# Altium schematic sheet C3_AI_V2.SchDoc
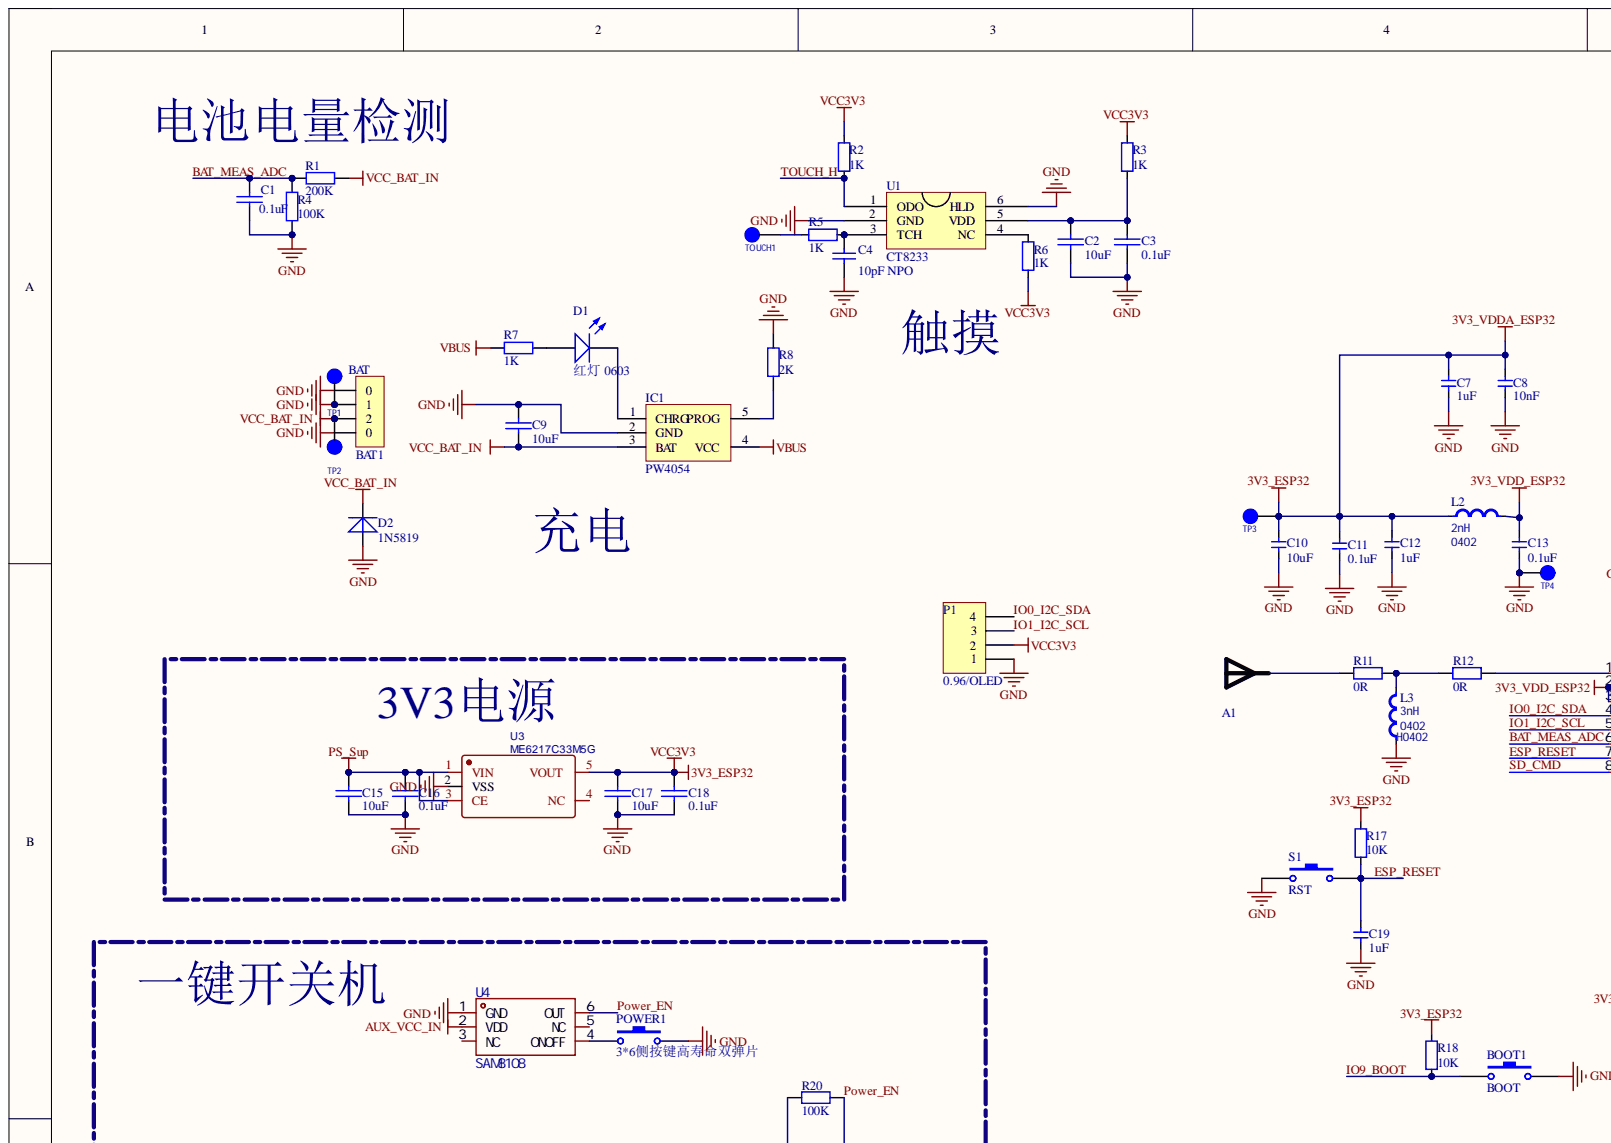
[diagram p0: C3_AI_V2.SchDoc, top left]
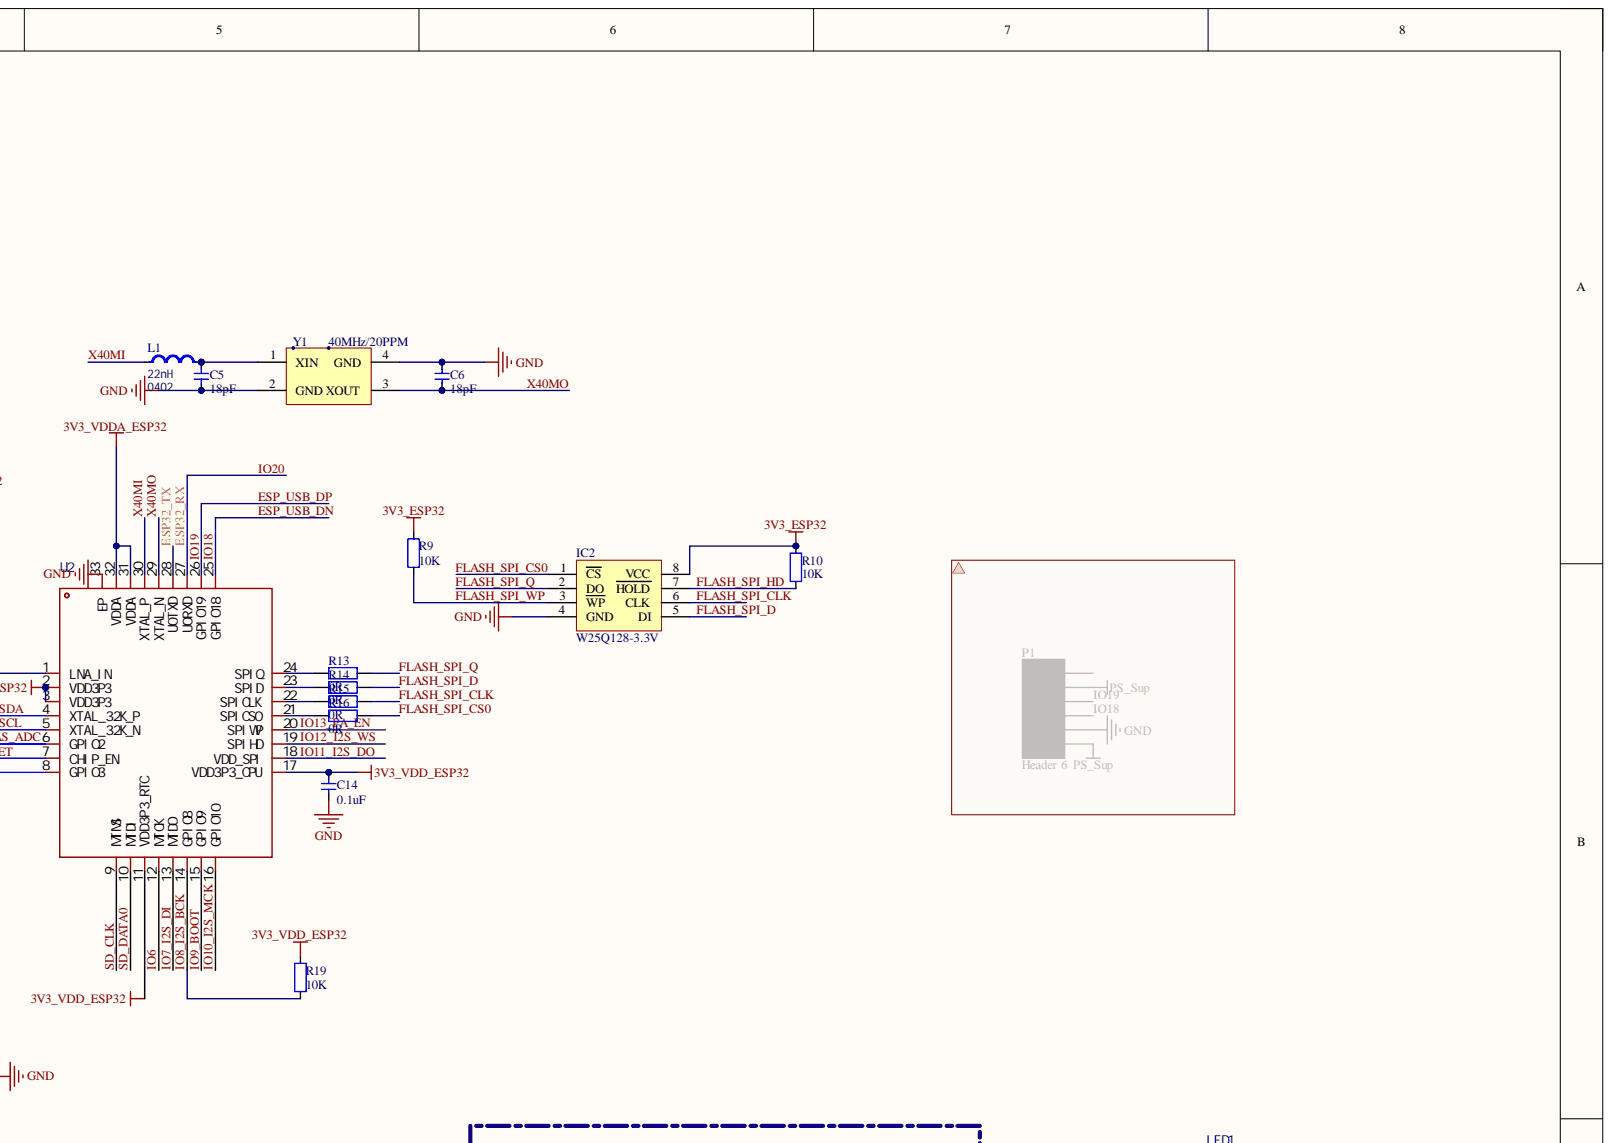
[diagram p1: C3_AI_V2.SchDoc, top right]
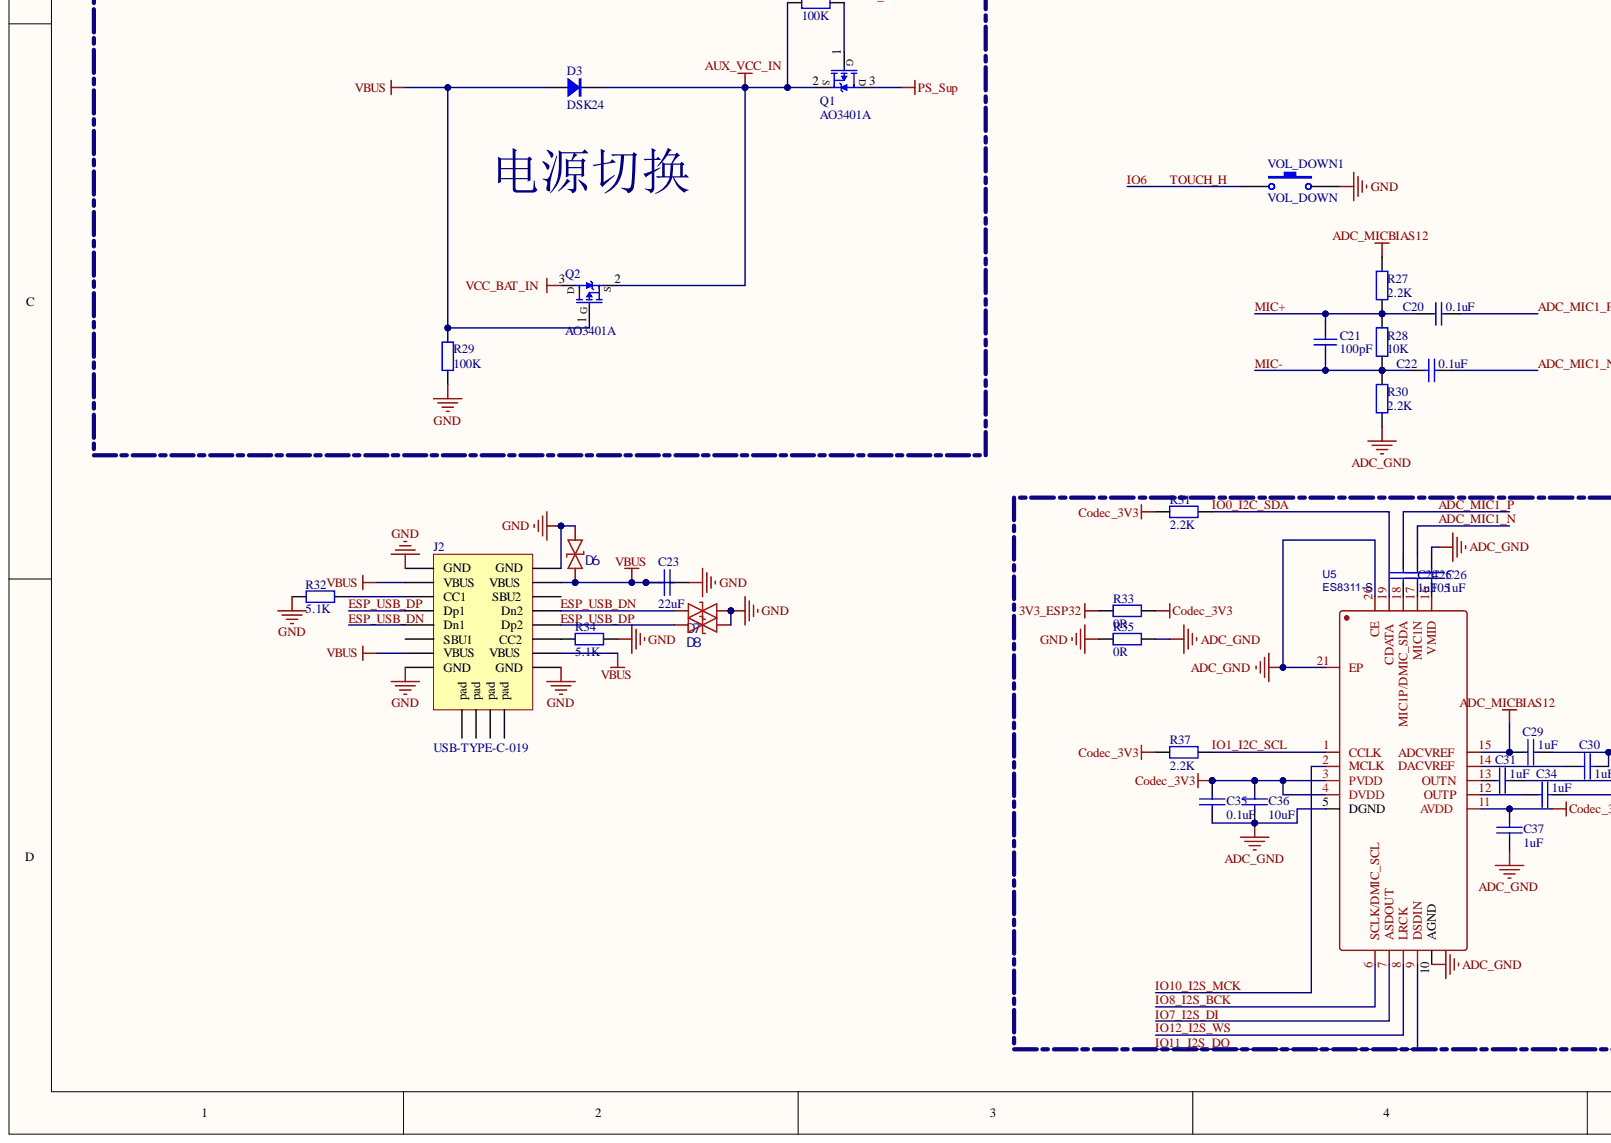
[diagram p2: C3_AI_V2.SchDoc, bottom left]
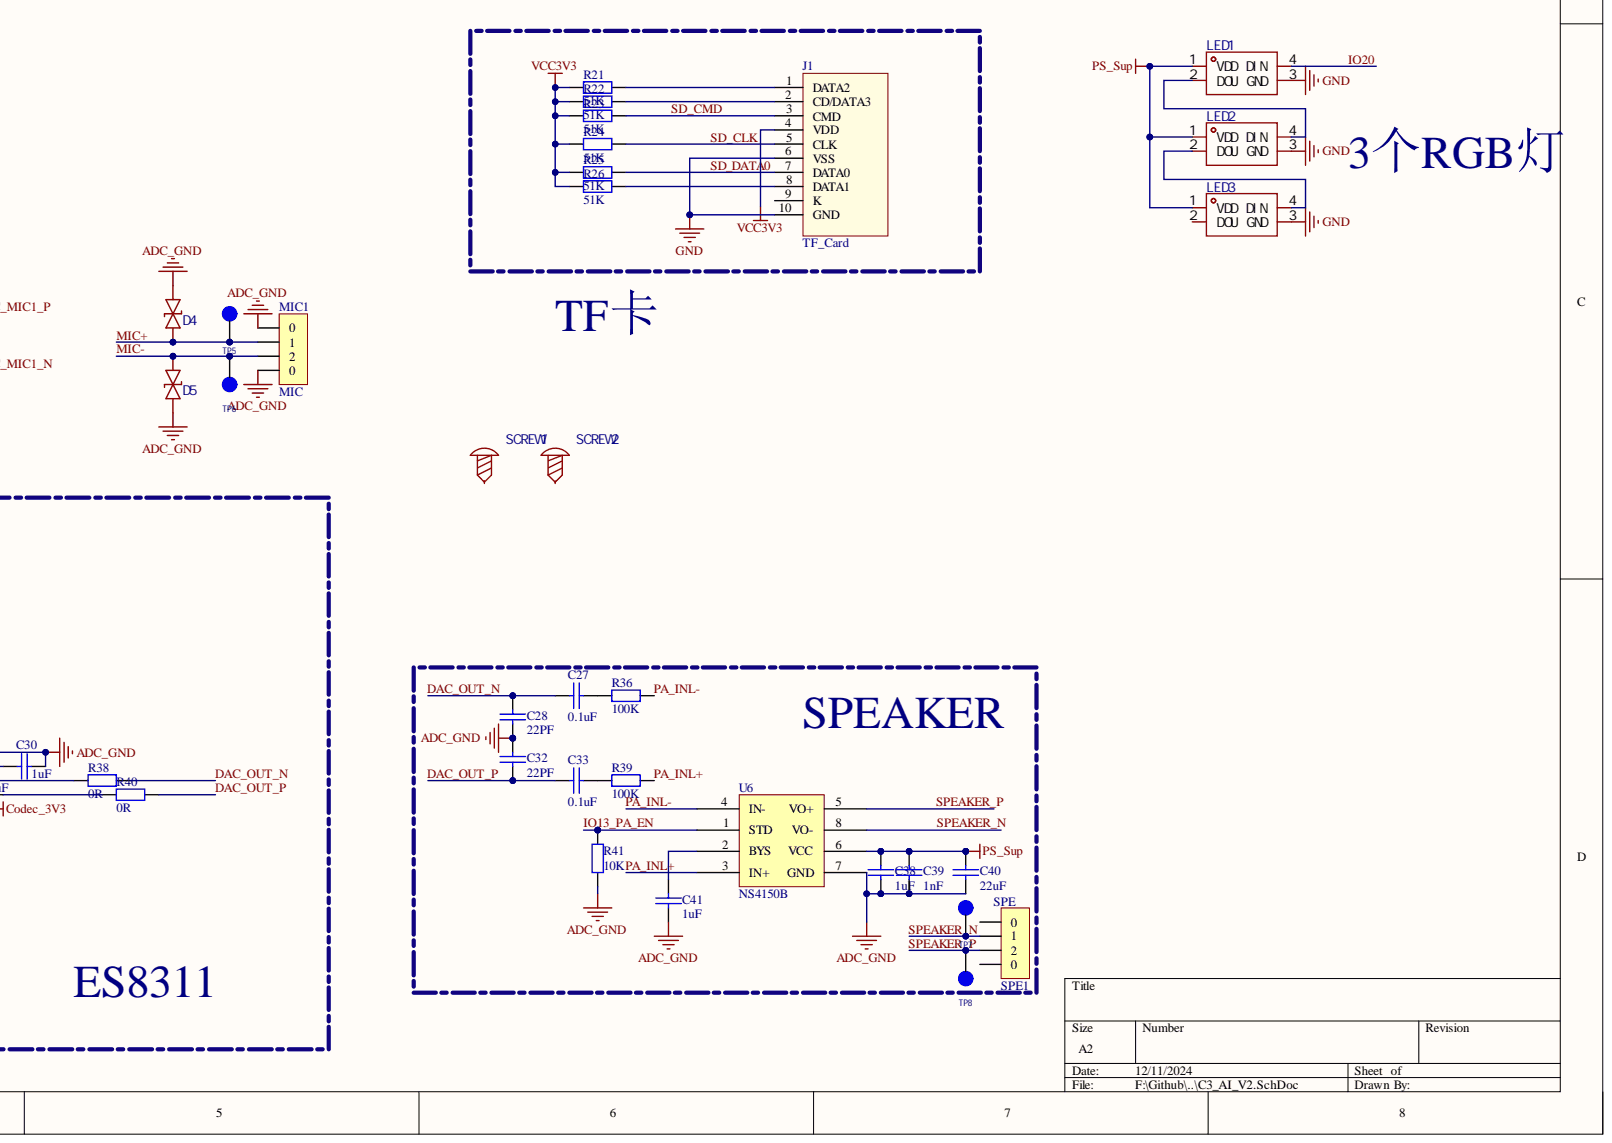
[diagram p3: C3_AI_V2.SchDoc, bottom right]

## Parts
A1  CA-C03 单极天线  [ANT-Rainsun-AN5120]  (value not drawn)
BAT1  BAT  [MOLEX 53398-0271]
BOOT1  BOOT  [TSW 3*6*4.3-S-W]
C1  0.1uF  [CAP_0402]
C2  10uF  [CAP_0402]
C3  0.1uF  [CAP_0402]
C4  10pF NPO  [CAP_0402]
C5  18pF  [CAP_0402]
C6  18pF  [CAP_0402]
C7  1uF  [CAP_0402]
C8  10nF  [CAP_0402]
C9  10uF  [C0603]
C10  10uF  [CAP_0402]
C11  0.1uF  [CAP_0402]
C12  1uF  [CAP_0402]
C13  0.1uF  [CAP_0402]
C14  0.1uF  [CAP_0402]
C15  10uF  [C0603]
C16  0.1uF  [CAP_0402]
C17  10uF  [C0603]
C18  0.1uF  [CAP_0402]
C19  1uF  [CAP_0402]
C20  0.1uF  [CAP_0402]
C21  100pF  [CAP_0402]
C22  0.1uF  [CAP_0402]
C23  22uF  [C0603]
C24  1uF  [CAP_0402]
C25  105  [CAP_0402]
C26  1uF  [CAP_0402]
C27  0.1uF  [CAP_0402]
C28  22PF  [CAP_0402]
C29  1uF  [CAP_0402]
C30  1uF  [CAP_0402]
C31  1uF  [CAP_0402]
C32  22PF  [CAP_0402]
C33  0.1uF  [CAP_0402]
C34  1uF  [CAP_0402]
C35  0.1uF  [CAP_0402]
C36  10uF  [CAP_0402]
C37  1uF  [CAP_0402]
C38  1uF  [CAP_0402]
C39  1nF  [CAP_0402]
C40  22uF  [C0603]
C41  1uF  [CAP_0402]
D1  红灯 0603  Package: 0603  [0603_LED_S1]
D2  1N5819  [SOD-323]
D3  DSK24  [SOD-123]
D4  LESD5D5.0CT1G  [SOD-523]  (value not drawn)
D5  LESD5D5.0CT1G  [SOD-523]  (value not drawn)
D6  LESD5D5.0CT1G  [SOD-523]  (value not drawn)
D7  LESD5D5.0CT1G  [SOD-523]  (value not drawn)
D8  LESD5D5.0CT1G  [SOD-523]  (value not drawn)
IC1  PW4054  [SOT23-5N]
IC2  W25Q128-3.3V  [SOP8-FLASH]
J1  TF_Card  [TF卡座]
J2  USB-TYPE-C-019  [USB Type-C-16Pin_A]
L1  value 22nH  Type: 0402  [IND_0402Q]  (hidden comment: H0402)
L2  value 2nH  Type: 0402  [IND_0402Q]  (hidden comment: H0402)
L3  H0402  value 3nH  Type: 0402  [IND_0402Q]
LED1  XL-5050RGBC-WS2812B  [LED-SMD_4P-L5.0-W5.0-BL_XL-5050RGBC]  (value not drawn)
LED2  XL-5050RGBC-WS2812B  [LED-SMD_4P-L5.0-W5.0-BL_XL-5050RGBC]  (value not drawn)
LED3  XL-5050RGBC-WS2812B  [LED-SMD_4P-L5.0-W5.0-BL_XL-5050RGBC]  (value not drawn)
MIC1  MIC  [MOLEX 53398-0271]
P1  0.96/OLED  [HDR1X4]
POWER1  3*6侧按键高寿命双弹片  [TSW 3*6*4.3-S-W]
Q1  AO3401A  [SOT23-3N]
Q2  AO3401A  [SOT23-3N]
R1  200K  [RES_0402]
R2  1K  [RES_0402]
R3  1K  [RES_0402]
R4  100K  [RES_0402]
R5  1K  [RES_0402]
R6  1K  [RES_0402]
R7  1K  [RES_0402]
R8  2K  [RES_0402]
R9  10K  [RES_0402]
R10  10K  [RES_0402]
R11  0R  [RES_0402]
R12  0R  [RES_0402]
R13  0R  [RES_0402]
R14  0R  [RES_0402]
R15  0R  [RES_0402]
R16  0R  [RES_0402]
R17  10K  [RES_0402]
R18  10K  [RES_0402]
R19  10K  [RES_0402]
R20  100K  [RES_0402]
R21  51K  [RES_0402]
R22  51K  [RES_0402]
R23  51K  [RES_0402]
R24  51K  [RES_0402]
R25  51K  [RES_0402]
R26  51K  [RES_0402]
R27  2.2K  [RES_0402]
R28  10K  [RES_0402]
R29  100K  [RES_0402]
R30  2.2K  [RES_0402]
R31  2.2K  [RES_0402]
R32  5.1K  [RES_0402]
R33  0R  [RES_0402]
R34  5.1K  [RES_0402]
R35  0R  [R 0805_L]
R36  100K  [RES_0402]
R37  2.2K  [RES_0402]
R38  0R  [RES_0402]
R39  100K  [RES_0402]
R40  0R  [RES_0402]
R41  10K  [RES_0402]
S1  RST  [TSW 3*6*4.3-S-W]
SCREW1  M3螺丝  [M3螺丝]  (value not drawn)
SCREW2  M3螺丝  [M3螺丝]  (value not drawn)
SPE1  SPE  [MOLEX 53398-0271]
TOUCH1  TP 1mm  [SMT Test Point]  (value not drawn)  (no BOM)
TP1  TP 1mm  [SMT Test Point]  (value not drawn)  (no BOM)
TP2  TP 1mm  [SMT Test Point]  (value not drawn)  (no BOM)
TP3  TP 1mm  [SMT Test Point]  (value not drawn)  (no BOM)
TP4  TP 1mm  [SMT Test Point]  (value not drawn)  (no BOM)
TP5  TP 1mm  [SMT Test Point]  (value not drawn)  (no BOM)
TP6  TP 1mm  [SMT Test Point]  (value not drawn)  (no BOM)
TP7  TP 1mm  [SMT Test Point]  (value not drawn)  (no BOM)
TP8  TP 1mm  [SMT Test Point]  (value not drawn)  (no BOM)
U1  CT8233  [SOT23-6N]
U2  ESP32-C3  [QFN-32_L5.0-W5.0-P0.50-TL-EP3.7]  (value not drawn)
U3  ME6217C33M5G  [SOT23-5N]
U4  SAM8108  [SOT23-6N]
U5  ES8311-S  [ES8311]
U6  NS4150B  [MSOP-8]
VOL_DOWN1  VOL_DOWN  [TSW 3*6*4.3-S-W]
Y1  40MHz/20PPM  [OSC 2025-4P]

## Nets
3V3_ESP32: C7.2 C8.2 C10.2 C11.2 C12.2 C17.2 C18.2 IC2.8(VCC) J1.4(VDD) L2.1 P1.2 R2.1 R3.1 R6.1 R9.1 R10.1 R17.1 R18.1 R21.1 R22.1 R23.1 R24.1 R25.1 R26.1 R33.2 TP3.1 U2.31(VDDA) U2.32(VDDA) U3.5(VOUT)
3V3_VDD_ESP32: C13.2 C14.2 L2.2 R19.1 U2.2(VDD3P3) U2.3(VDD3P3) U2.11(VDD3P3_RTC) U2.17(VDD3P3_CPU)
ADC_GND: C26.2 C28.2 C29.1 C30.2 C32.1 C35.2 C36.2 C37.2 C38.2 C39.2 C40.2 C41.2 D4.2 D5.2 MIC1.0 R30.2 R35.1 R41.2 U5.5(DGND) U5.10(AGND) U5.20(CE) U5.21(EP) U6.7(GND)
ADC_MICBIAS12: C29.2 R27.1 U5.15(ADCVREF)
AUX_VCC_IN: D3.2 Q1.2 Q2.2 R20.1 U4.2(VDD)
Codec_3V3: C35.1 C36.1 C37.1 R31.2 R33.1 R37.2 U5.3(PVDD) U5.4(DVDD) U5.11(AVDD)
GND: BAT1.0 BAT1.1 BOOT1.2 C1.1 C2.1 C3.1 C4.1 C5.1 C6.2 C7.1 C8.1 C9.2 C10.1 C11.1 C12.1 C13.1 C14.1 C15.1 C16.1 C17.1 C18.1 C19.1 C23.1 D2.1 D6.2 D7.2 D8.2 IC1.2(GND) IC2.4(GND) J1.6(VSS) J1.10(GND) J2.A1(GND) J2.A12(GND) J2.B1(GND) J2.B12(GND) L3.1 LED1.3(GND) LED2.3(GND) LED3.3(GND) P1.1 POWER1.2 R4.1 R8.2 R29.1 R32.1 R34.2 R35.2 S1.1 TP1.1 TP4.1 U1.2(GND) U1.6(HLD) U2.33(EP) U3.2(VSS) U4.1(GND) VOL_DOWN1.2 Y1.2(GND) Y1.4(GND)
PS_Sup: C15.2 C16.2 C38.1 C39.1 C40.1 LED1.1(VDD) LED2.1(VDD) LED3.1(VDD) Q1.3 U3.1(VIN) U3.3(CE) U6.6(VCC)
VBUS: C23.2 D3.1 D6.1 IC1.4(VCC) J2.A4(VBUS) J2.A9(VBUS) J2.B4(VBUS) J2.B9(VBUS) Q2.1 R7.2 R29.2
VCC_BAT_IN: BAT1.2 C9.1 D2.2 IC1.3(BAT) Q2.3 R1.2 TP2.1
ADC_MIC1_N: C22.2 C25.2
ADC_MIC1_P: C20.2 C24.2
BAT_MEAS_ADC: C1.2 R1.1 R4.2 U2.6(GPIO2)
DAC_OUT_N: C27.2 C28.1 R38.1
DAC_OUT_P: C32.2 C33.2 R40.1
ESP32_RX: LED1.4(DIN) U2.27(U0RXD)
ESP32_TX: U2.28(U0TXD)
ESP_RESET: C19.2 R17.2 S1.2 U2.7(CHIP_EN)
ESP_USB_DN: D7.1 J2.A7(Dn1) J2.B7(Dn2) U2.25(GPIO18)
ESP_USB_DP: D8.1 J2.A6(Dp1) J2.B6(Dp2) U2.26(GPIO19)
FLASH_SPI_CLK: IC2.6(CLK) R15.1
FLASH_SPI_CS0: IC2.1(CS) R16.1
FLASH_SPI_D: IC2.5(DI) R14.1
FLASH_SPI_HD: IC2.7(HOLD) R10.2
FLASH_SPI_Q: IC2.2(DO) R13.1
FLASH_SPI_WP: IC2.3(WP) R9.2
IO0_I2C_SDA: P1.4 R31.1 U2.4(XTAL_32K_P) U5.19(CDATA)
IO1_I2C_SCL: P1.3 R37.1 U2.5(XTAL_32K_N) U5.1(CCLK)
IO6: R2.2 U1.1(ODO) U2.12(MTCK) VOL_DOWN1.1
IO7_I2S_DI: U2.13(MTDO) U5.7(ASDOUT)
IO8_I2S_BCK: R19.2 U2.14(GPIO8) U5.6(SCLK/DMIC_SCL)
IO9_BOOT: BOOT1.1 R18.2 U2.15(GPIO9)
IO10_I2S_MCK: U2.16(GPIO10) U5.2(MCLK)
IO11_I2S_DO: U2.18(VDD_SPI) U5.9(DSDIN)
IO12_I2S_WS: U2.19(SPIHD) U5.8(LRCK)
IO13_PA_EN: R41.1 U2.20(SPIWP) U6.1(STD)
MIC+: C20.1 C21.2 D4.1 MIC1.1 R27.2 R28.1 TP5.1
MIC-: C21.1 C22.1 D5.1 MIC1.2 R28.2 R30.1 TP6.1
PA_INL+: R39.2 U6.3(IN+)
PA_INL-: R36.2 U6.4(IN-)
Power_EN: Q1.1 R20.2 U4.6(OUT)
SD_CLK: J1.5(CLK) R24.2 U2.9(MTMS)
SD_CMD: J1.3(CMD) R23.2 U2.8(GPIO3)
SD_DATA0: J1.7(DATA0) R25.2 U2.10(MTDI)
SPEAKER_N: SPE1.1 TP7.1 U6.8(VO-)
SPEAKER_P: SPE1.2 TP8.1 U6.5(VO+)
X40MI: L1.1 U2.30(XTAL_P)
X40MO: C6.1 U2.29(XTAL_N) Y1.3(XOUT)
NetA1_1: A1.1 R11.2
NetC2_2: C2.2 C3.2 R3.2 U1.5(VDD)
NetC4_2: C4.2 R5.2 U1.3(TCH)
NetC5_2: C5.2 L1.2 Y1.1(XIN)
NetC24_1: C24.1 U5.18(MIC1P/DMIC_SDA)
NetC25_1: C25.1 U5.17(MIC1N)
NetC26_1: C26.1 U5.16(VMID)
NetC27_1: C27.1 R36.1
NetC30_1: C30.1 U5.14(DACVREF)
NetC31_1: C31.1 R38.2
NetC31_2: C31.2 U5.13(OUTN)
NetC33_1: C33.1 R39.1
NetC34_1: C34.1 R40.2
NetC34_2: C34.2 U5.12(OUTP)
NetC41_1: C41.1 U6.2(BYS)
NetD1_A: D1.A R7.1
NetD1_K: D1.K IC1.1(CHRG)
NetIC1_5: IC1.5(PROG) R8.1
NetJ1_1: J1.1(DATA2) R21.2
NetJ1_2: J1.2(CD/DATA3) R22.2
NetJ1_8: J1.8(DATA1) R26.2
NetJ2_A5: J2.A5(CC1) R32.2
NetJ2_B5: J2.B5(CC2) R34.1
NetL3_2: L3.2 R11.1 R12.2
NetLED1_2: LED1.2(DOU) LED2.4(DIN)
NetLED2_2: LED2.2(DOU) LED3.4(DIN)
NetPOWER1_1: POWER1.1 U4.4(ONOFF)
NetR5_1: R5.1 TOUCH1.1
NetR6_2: R6.2 U1.4(NC)
NetR12_1: R12.1 U2.1(LNA_IN)
NetR13_2: R13.2 U2.24(SPIQ)
NetR14_2: R14.2 U2.23(SPID)
NetR15_2: R15.2 U2.22(SPICLK)
NetR16_2: R16.2 U2.21(SPICS0)

Not connected: J1 9(K); J2 0(pad) A8(SBU1) B8(SBU2); LED3 2(DOU); SCREW1 1; SCREW2 1; SPE1 0; U3 4(NC); U4 3(NC) 5(NC)

## Library data of the parts
A1 = ANT-Rainsun-AP5120: Component Kind: Standard; Library Name: OBIT_TESLA.IntLib
BAT1 SPE1 = Header 4: molex 53261-0271 2P; BOM名称: 4P接插件; 版权归属: 源创客; Component Kind: Standard; Library Name: 3D Mode.IntLib; 学习资源: www.ooocke.com
BOOT1 S1 VOL_DOWN1 = SW-PB: 3*6侧按键高寿命双弹片; Component Kind: Standard; LatestRevisionDate: 17-Jul-2002; LatestRevisionNote: Re-released for DXP Platform.; PackageDescription: Switch; 2 Leads; PackageReference: SPST-2; Published: 24-Mar-1999; Library Name: 自创 (1).SchLib; Publisher: Altium Limited
C1-C3 C9 C15-C18 C23 = cap: Component Kind: Standard; Library Name: 自创.SchLib
C4 = Cap: 贴片电容; Value: 0.1uF; Component Kind: Standard; LatestRevisionDate: 17-Jul-2002; LatestRevisionNote: Re-released for DXP Platform.; Library Name: Miscellaneous Devices.IntLib; PackageDescription: Radial Cap, Thru-Hole; 2 Leads; 0.3 in Pin Spacing; PackageReference: RAD-0.3; Published: 8-Jun-2000; Signal Integrity: Cap; Simulation: CAP; Publisher: Altium Limited
C5-C8 C10-C14 C19 = C_0402: Capacitor; Value: 0.1u; Component Kind: Standard; Library Name: OBIT_TESLA.IntLib
C20 C22 = Cap: 贴片电容; Component Kind: Standard; LatestRevisionDate: 17-Jul-2002; LatestRevisionNote: Re-released for DXP Platform.; Library Name: Miscellaneous Devices.IntLib; PackageDescription: Radial Cap, Thru-Hole; 2 Leads; 0.3 in Pin Spacing; PackageReference: RAD-0.3; Published: 8-Jun-2000; Signal Integrity: Cap; Simulation: CAP; Value: 0.1uF; Publisher: Altium Limited
C21 = Cap: 贴片电容; Value: 220pF; Component Kind: Standard; LatestRevisionDate: 17-Jul-2002; LatestRevisionNote: Re-released for DXP Platform.; Library Name: Miscellaneous Devices.IntLib; PackageDescription: Radial Cap, Thru-Hole; 2 Leads; 0.3 in Pin Spacing; PackageReference: RAD-0.3; Published: 8-Jun-2000; Signal Integrity: Cap; Simulation: CAP; Publisher: Altium Limited
C24-C41 = CAP: Component Kind: Standard; Library Name: XKZ.SchLib
D1 = 0603 白灯: 发光二极管; Component Kind: Standard; ComponentLink1Description: 供应商链接; ComponentLink1URL: http://www.szlcsc.com/product/details_2663.html; Library Name: Miscellaneous Devices LC.IntLib; Supplier: LC; SuppliersPartNumber: C2290
D2 = 1N4007: 二极管; Component Kind: Standard; Library Name: NT-SchLibrary.SchLib
D3 = SS34: 肖特基二极管; 属性: 40V/3A; 版权归属: 源创客; BOM名称: 肖特基二极管; Component Kind: Standard; Library Name: 8.1   - 二极管-整流桥.SchLib; 学习资源: www.ooocke.com
D4-D8 = LESD5D5.0CT1G: 极性:双向;反向截止电压(Vrwm):5V;最大钳位电压:18.6V;峰值脉冲电流(Ipp)@10/1000us:9.4A;击穿电压:5.6V;; Manufacturer: LRC(乐山无线电); Add into BOM: yes; Breakdown Voltage: 5.6V; Maximum Clamping Voltage: 18.6V; Peak Pulse Current (Ipp)@10/1000us: 9.4A; polarity: 双向; Reverse Stand-Off Voltage (Vrwm): 5V; Type: ESD; Component Kind: Standard; Convert to PCB: yes; Datasheet: https://atta.szlcsc.com/upload/public/pdf/source/20190807/C383211_633DF4B4E2C161403226E5235FE33A1C.pdf; Device: LESD5D5.0CT1G; JLCPCB Part Class: 扩展库; LCSC Part Name: 双向ESD; Manufacturer Part: LESD5D5.0CT1G; Supplier: LCSC; Supplier Footprint: SOD-523; Supplier Part: C383211; Symbol: LESD5D5.0CT1G
IC1 = LTC4054: 锂电池充电芯片; 版权归属: 源创客; BOM名称: 锂电池充电芯片; Component Kind: Standard; Library Name: 1.3   - 电源类芯片.SchLib; 学习资源: www.ooocke.com
IC2 = W25Qxx: 外置Flash 1.8V; 版权归属: 源创客; BOM名称: 外置Flash; Component Kind: Standard; Library Name: 3D库.IntLib; 学习资源: www.ooocke.com
J1 = TF_Card: TF卡座; 版权归属: 源创客; BOM名称: TF卡座; Component Kind: Standard; Library Name: Source.IntLib; 学习资源: www.ooocke.com
J2 = USB_C: Type-C 母 卧贴 https://item.szlcsc.com/3252508.html; Component Kind: Standard; Library Name: XKZ.SchLib
L1 L2 = Ind_0402: Inductor; Specification: ±5% 100mA; Component Kind: Standard; Library Name: OBIT_TESLA.IntLib
L3 = Ind_0402: 3nH电感 0402; Specification: ±5% 100mA; Component Kind: Standard; Library Name: OBIT_TESLA.IntLib
LED1-LED3 = XL-5050RGBC-WS2812B: 5050七彩幻彩透明贴片LED灯珠 5050 幻彩透明四脚 ws2812B 内置驱动IC; Manufacturer: XINGLIGHT(成兴光); Add into BOM: yes; Component Kind: Standard; Convert to PCB: yes; Datasheet: https://atta.szlcsc.com/upload/public/pdf/source/20240412/E1004CAA80592E31D08BFE019083806F.pdf; Device: XL-5050RGBC-WS2812B; JLCPCB Part Class: 扩展库; LCSC Part Name: XL-5050RGBC-WS2812B; Manufacturer Part: XL-5050RGBC-WS2812B; Supplier: LCSC; Supplier Footprint: SMD5050; Supplier Part: C2843785; Symbol: XL-5050RGBC-WS2812B
MIC1 = Header 4: 立贴 MX1.25mm间距 连接器; BOM名称: 4P接插件; 版权归属: 源创客; Component Kind: Standard; Library Name: 3D Mode.IntLib; 学习资源: www.ooocke.com
P1 = Header 4: Header, 4-Pin; Component Kind: Standard; LatestRevisionDate: 17-Jul-2002; LatestRevisionNote: Re-released for DXP Platform.; Library Name: Miscellaneous Connectors.IntLib; Signal Integrity: Connector; Publisher: Altium Limited
POWER1 = SW-PB: Component Kind: Standard; Description: 3*6侧按键高寿命双弹片; LatestRevisionDate: 17-Jul-2002; LatestRevisionNote: Re-released for DXP Platform.; PackageDescription: Switch; 2 Leads; PackageReference: SPST-2; Published: 24-Mar-1999; Library Name: 自创 (1).SchLib; Publisher: Altium Limited
Q1 Q2 = AO3401: P沟道 30V/4.2A; BOM名称: 场效应管; 版权归属: 源创客; Component Kind: Standard; Library Name: 6.1   - 场效应管-可控硅.SchLib; 学习资源: www.ooocke.com; 描述: P沟道 30V/4.2A
R1 R4 R7 R8 R11-R16 R20-R41 = RES: 普通电阻; Component Kind: Standard; Library Name: LIB_MANGO.IntLib
R2 R3 R5 R6 R9 R10 R17-R19 = R-0402: Resistor; Value: 22R; Component Kind: Standard; Library Name: OBIT_TESLA.IntLib
SCREW1 SCREW2 = M3螺丝: Add into BOM: yes; Symbol: 螺丝孔; Component Kind: Standard; Convert to PCB: yes; Device: M3螺丝; Supplier Footprint: 插件
TOUCH1 TP1-TP8 = TP 1mm: PCB TEST POINT SMT 1mm; Creation date: 26-Apr-2012; Created by: gmoreno; Library Name: OBIT_TESLA.IntLib
U1 = TH6A9: 触摸IC; Component Kind: Standard
U2 = ESP32-C3: CPU内核:RISC-V;CPU最大主频:160MHz;工作电压范围:3V~3.6V;程序存储容量:-;程序存储器类型:-;RAM总容量:400KB;GPIO端口数量:22;; Manufacturer: ESPRESSIF(乐鑫); ADC (Bit): 12bit; Add into BOM: yes; communication protocol: 蓝牙协议；WIFI协议; CPU Core: RISC-V; CPU Maximum Speed: 160MHz; Direct Memory Access: 有; GPIO Ports Number: 22; I2C: 1; Peripheral/Function: 蓝牙协议栈；片载温度传感器；真随机数发生器；DMA；看门狗；WIFI协议栈；硬件密码算法引擎；54位Timer；PWM；RTC实时时钟; RAM Size: 400KB; SPI: 3; UART/USART: 2; CCP Capture/Compare: -; Component Kind: Standard; Convert to PCB: yes; DAC (Bit): -; Datasheet: https://atta.szlcsc.com/upload/public/pdf/source/20210625/C2838500_6489BE4707B1A2880AF92BF6724D19CA.pdf; Device: ESP32-C3; EEPROM: -; I2S: 1; Infrared Data Association: -; Internal Comparator: -; Internal Oscillator: -; JLCPCB Part Class: 扩展库; LCSC Part Name: ESP32-C3; Low-Voltage Detect: -; Manufacturer Part: ESP32-C3; Operating Temperature Range: -40℃~+105℃; Operating Voltage Range: 3V~3.6V; PCB3D: QFN-32_L5.0-W5.0-H0.9-P0.50-TL-EP3.7; Program Memory Type: -; Program Storage Size: -; PWM (Bit): -; Real-Time Clock: 有; Secure Digital Input and Output: -; Supplier: LCSC; Supplier Footprint: QFN-32-EP(5x5); Supplier Part: C2838500; Symbol: ESP32-C3; Universal Serial Bus: -; Watchdog: 有
U3 = ME6211C28M5G: BOM_Manufacturer: MICRONE(南京微盟); BOM_Manufacturer Part: ME6211C28M5G-N; BOM_JLCPCB Part Class: Extended Part; BOM_Supplier: LCSC; BOM_Supplier Part: C53099; Component Kind: Standard; spicePre: U; spiceSymbolName: ME6211C28M5G
U4 = SAM8108: 按键触发开关 IC; Add into BOM: yes; Component Kind: Standard; Convert to PCB: yes; Device: SAM8108; JLCPCB Part Class: 扩展库; LCSC Part Name: SAM8108; Manufacturer Part: SAM8108; Supplier: LCSC; Supplier Footprint: SOT-23-6; Supplier Part: C9900019002; Symbol: SAM8108
U5 = ES8311-S: BOM_Manufacturer: null; Contributor: lcsc; BOM_JLCPCB Part Class: Extended Part; BOM_Manufacturer Part: ES8311-S; BOM_Supplier: LCSC; BOM_Supplier Part: C9900015232; Component Kind: Standard; spicePre: U; spiceSymbolName: ES8311-S
U6 = LM4871: Component Kind: Standard; Library Name: MyPcbLib.IntLib
Y1 = XT-4Pin: XT-2520; MF: ECS International; MP: ECS-3225S30-240-EN-TR; Availability: Unavailable; Component Kind: Standard; Package: SMD-6 ECS International; Price: 0.75 USD; Library Name: OBIT_TESLA.IntLib
